# Altium schematic sheet Э3("All Documents",Physical)
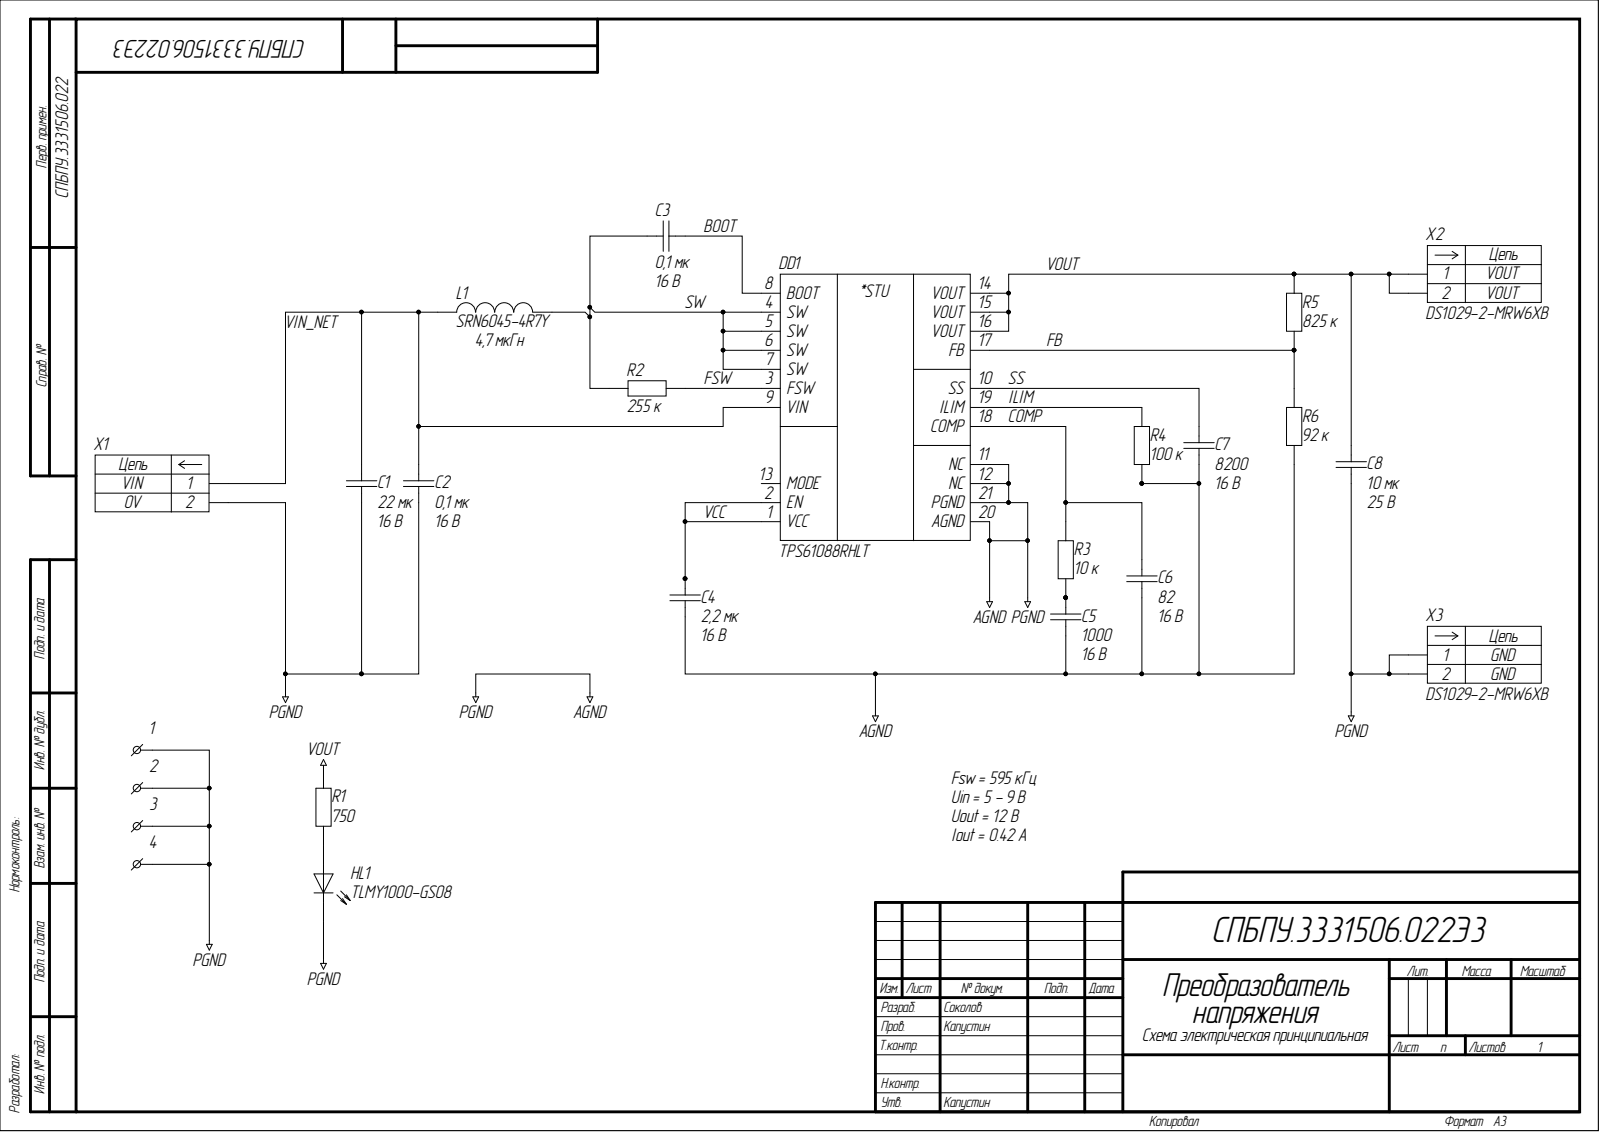
[diagram p0: Э3("All Documents",Physical)]

## Parts
1  MOUNTING_HOLE  [HOLE_1,6X3,3]  (value not drawn)
2  MOUNTING_HOLE  [HOLE_1,6X3,3]  (value not drawn)
3  MOUNTING_HOLE  [HOLE_1,6X3,3]  (value not drawn)
4  MOUNTING_HOLE  [HOLE_1,6X3,3]  (value not drawn)
C1  22 мк  [C1206]
C2  0,1 мк  Номинальное напряжение: 16 В  [C0402]
C3  0,1 мк  Номинальное напряжение: 16 В  [C0402]
C4  2,2 мк  Номинальное напряжение: 16 В  [C0603]
C5  1000  Номинальное напряжение: 16 В  [C0201]
C6  82  Номинальное напряжение: 16 В  [C0603]
C7  8200  Номинальное напряжение: 16 В  [C0402]
C8  10 мк  Номинальное напряжение: 25 В  [C0603]
DD1  TPS61088RHLT  [VQFN-20]
HL1  TLMY1000-GS08  [HL0603]
L1  SRN6045-4R7Y  Параметр номинал: 4,7 мкГн  [SRN6045_1]
R1  750  [R0603]
R2  255 к  [R0603]
R3  10 к  [R0603]
R4  100 к  [R0603]
R5  825 к  [R0603]
R6  92 к  [R0402]
X1  Contact_2: 0V  Contact_1: VIN  [DS1029-2-MRW6XB]
X2  DS1029-2-MRW6XB  Contact_2: VOUT  [DS1029-2-MRW6XB]
X3  DS1029-2-MRW6XB  Contact_2: GND  [DS1029-2-MRW6XB]

## Nets
AGND: 1.1 2.1 3.1 4.1 C1.1 C2.1 C4.1 C5.1 C6.2 C7.1 C8.1 DD1.11(NC) DD1.12(NC) DD1.20(AGND) DD1.21(PGND) HL1.1 R4.2 R6.2 X1.2 X3.1 X3.2
BOOT: C3.2 DD1.8(BOOT)
COMP: C6.1 DD1.18(COMP) R3.1
FB: DD1.17(FB) R5.2 R6.1
FSW: DD1.3(FSW) R2.2
ILIM: DD1.19(ILIM) R4.1
SS: C7.2 DD1.10(SS)
SW: C3.1 DD1.4(SW) DD1.5(SW) DD1.6(SW) DD1.7(SW) L1.2 R2.1
VCC: C4.2 DD1.1(VCC) DD1.2(EN)
VIN_NET: C1.2 C2.2 DD1.9(VIN) L1.1 X1.1
VOUT: C8.2 DD1.14(VOUT) DD1.15(VOUT) DD1.16(VOUT) R1.1 R5.1 X2.1 X2.2
NetC5_2: C5.2 R3.2
NetDD1_13: DD1.13(MODE)
NetHL1_2: HL1.2 R1.2

## Library data of the parts
1-4 = fstn0011: Параметры металлизации: 3,3 мм; Описание: Отверстие под М1,5; Наименование: Отверстие 1,6x3,3; Диаметр отверстия: 1,6 мм; Database Table Name: Монтажные элементы$; id: fstn0011; Component Kind: Standard; Library Name: kravt_lib.DbLib
C1 = c0103: =Описание; Фамилия: Паньков; Температурный коэффициент емкости: X7R; Рабочие температуры: от –55 °С до +125 °С; Производитель: YAGEO Corp.; Отклонение: ±10 %; Описание: Конденсатор чип 0805 22 мкФ 16 В ±10 %; Номинальное напряжение: 16 В; Наименование: CC1206KRX7R7BB226; Корпус: 1206; Database Table Name: Конденсаторы$; Номинал: 22 мк; Напряжение: 16 В; ID: c0103; HelpURL: https://www.yageo.com/upload/media/product/productsearch/datasheet/mlcc/UPY-GPHC_X7R_6.3V-to-50V_20.pdf; Description: Конденсатор чип 0805 22 мкФ 16 В ±10 %; Component Kind: Standard; Library Name: Database_Students.DbLib
C2 C3 = c0028: =Описание; Фамилия: Паньков; Температурный коэффициент емкости: X5R; Рабочие температуры: от –55 °С до +85 °С; Производитель: YAGEO Corp.; Отклонение: ±10 %; Описание: Конденсатор чип 0402 100 нФ 16 В ±10 %; Наименование: CC0402KRX5R7BB104; Корпус: 0402; Database Table Name: Конденсаторы$; Номинал: 0,1 мк; Напряжение: 16 В; ID: c0028; HelpURL: https://www.yageo.com/upload/media/product/productsearch/datasheet/mlcc/UPY-GPHC_X5R_4V-to-50V_26.pdf; Description: Конденсатор чип 0402 100 нФ 16 В ±10 %; Component Kind: Standard; Library Name: Database_Students.DbLib
C4 = c0069: =Описание; Фамилия: Паньков; Температурный коэффициент емкости: X5R; Рабочие температуры: от –55 °С до +85 °С; Производитель: YAGEO Corp.; Отклонение: ±10 %; Описание: Конденсатор чип 0603 2,2 мкФ 16 В ±10 %; Наименование: CC0603KRX5R7BB225; Корпус: 0603; Database Table Name: Конденсаторы$; Номинал: 2,2 мк; Напряжение: 16 В; ID: c0069; HelpURL: https://www.yageo.com/upload/media/product/productsearch/datasheet/mlcc/UPY-GPHC_X5R_4V-to-50V_26.pdf; Description: Конденсатор чип 0603 2,2 мкФ 16 В ±10 %; Component Kind: Standard; Library Name: Database_Students.DbLib
C5 = c0119: =Описание; Фамилия: Соколов; Температурный коэффициент емкости: X7R; Рабочие температуры: от –55 °С до +125 °С; Производитель: Murata Electronics; Отклонение: ±10 %; Описание: Конденсатор чип 0201 1000 пФ 16 В ±10 %; Наименование: GRM033R71C102KA01D; Корпус: 0201; Database Table Name: Конденсаторы$; Номинал: 1000; Напряжение: 16 В; ID: c0119; HelpURL: https://datasheet.octopart.com/GRM033R71C102KA01D-Murata-datasheet-14399077.pdf; Description: Конденсатор чип 0201 1000 пФ 16 В ±10 %; Component Kind: Standard; Library Name: Database_Students.DbLib
C6 = c0011: =Описание; Фамилия: Ларионов; Температурный коэффициент емкости: C0G; Рабочие температуры: от –55 °С до +125 °С; Производитель: YAGEO Corp.; Отклонение: ±5 %; Описание: Конденсатор чип 0603 82 пФ 16 В ±5 %; Наименование: CC0603JRNPO7BN820; Корпус: 0603; Database Table Name: Конденсаторы$; Номинал: 82; Напряжение: 16 В; ID: c0011; HelpURL: https://www.yageo.com/upload/media/product/productsearch/datasheet/mlcc/UPY-GP_NP0_16V-to-50V_18.pdf; Description: Конденсатор чип 0603 82 пФ 16 В ±5 %; Component Kind: Standard; Library Name: Database_Students.DbLib
C7 = c0120: =Описание; Фамилия: Соколов; Температурный коэффициент емкости: C0G; Рабочие температуры: от –55 °С до +125 °С; Производитель: Murata Electronics; Отклонение: ±5 %; Описание: Конденсатор чип 0402 8,2 нФ 50 В ±5 %; Наименование: GRM155R71C822KA01D; Корпус: 0402; Database Table Name: Конденсаторы$; Номинал: 8200; Напряжение: 16 В; ID: c0120; HelpURL: https://ru.mouser.com/datasheet/2/281/murata_03052018_GRM_Series_1-1310166.pdf; Description: Конденсатор чип 0402 8,2 нФ 50 В ±5 %; Component Kind: Standard; Library Name: Database_Students.DbLib
C8 = c0090: =Описание; Фамилия: Паньков; Температурный коэффициент емкости: X5R; Рабочие температуры: от –55 °С до +85 °С; Производитель: YAGEO Corp.; Отклонение: ±10 %; Описание: Конденсатор чип 0603 10 мкФ 25 В ±10 %; Наименование: CC0603KRX5R8BB106; Корпус: 0603; Database Table Name: Конденсаторы$; Номинал: 10 мк; Напряжение: 25 В; ID: c0090; HelpURL: https://www.yageo.com/upload/media/product/productsearch/datasheet/mlcc/UPY-GPHC_X5R_4V-to-50V_26.pdf; Description: Конденсатор чип 0603 10 мкФ 25 В ±10 %; Component Kind: Standard; Library Name: Database_Students.DbLib
DD1 = dd0009: =Описание; Частота переключения: от 200 кГц до 2,2 МГц; Фамилия: Соколов; Рабочие температуры: от -40 °С до +125 °С; Производитель: Texas Instruments; Описание: Повышающий преобразователь напряжения 12,6 и 10А; Коммутируемый ток: 10А; Выходное напряжение: от 4,5 В до 12,6 В; Входное напряжение: от 2,7 В до 12 В; Database Table Name: Преобразователи напряжения$; Наименование: TPS61088RHLT; Корпус: VQFN-20; ID: dd0009; HelpURL: https://www.ti.com/lit/ds/symlink/tps61088.pdf?ts=1606203432287&ref_url=https%253A%252F%252Fwww.google.com%252F; Description: Повышающий преобразователь напряжения 12,6 и 10А; Component Kind: Standard; Library Name: Database_Students.DbLib
HL1 = hl0003: =Описание; Цвет: Yellow; Фамилия: Ларионов; Рассеиваемая мощность: 40 мВт; Рабочие температуры: от -40 °С до +100 °С; Производитель: Vishay Intertechnology, Inc.; Описание: Светодиод чип 0603 желтый; Номинальный прямой ток: 15 мА; Номинальное падение напряжения: 1,8 В; Номинальная сила света: 7,5 мкд; Номинальная длина волны: 588 нм; Корпус: 0603; Database Table Name: Светодиоды$; Наименование: TLMY1000-GS08; ID: hl0003; HelpURL: https://www.vishay.com/leds/list/product-83172/; Description: Светодиод чип 0603 желтый; Component Kind: Standard; Library Name: Database_Students.DbLib
L1 = l0003: =Описание; Частота резонанса: 7,96 МГц; Фамилия: Соколов; Ток насыщения: 3,5 А; Рабочие температуры: от -40 °С до +125 °С; Рабочее напряжение: не указано; Производитель: Bourns, Inc.; Отклонение: ±30 %; Описание: Индуктивность 4,7 мкГн ±30 %; Номинальный ток (при нагреве на 20 °С/40 °С): 3 А; Максимальное активное сопротивление: 37.6 мОм; Корпус: 6,0 x 6,0 x 4,5; Database Table Name: Индуктивности$; Номинал: 4,7 мкГн; Наименование: SRN6045-4R7Y; ID: l0003; HelpURL: https://ru.mouser.com/datasheet/2/54/srn6045-1391386.pdf; Description: Индуктивность 4,7 мкГн ±30 %; Component Kind: Standard; Library Name: Database_Students.DbLib
R1 = r0028: =Описание; Фамилия: Паньков; Рабочие температуры: от –55 °С до +155 °С; Производитель: YAGEO Corp.; Отклонение: ±1 %; Описание: Резистор чип 0603 750 Ом ±1 % 0,1 Вт; Номинальный ток: 1 А; Номинальное напряжение: 75 В; Наименование: RC0603FR-07750RL; Корпус: 0603; Database Table Name: Резисторы$; Номинал: 750; ID: r0028; HelpURL: https://www.yageo.com/upload/media/product/productsearch/datasheet/rchip/PYu-RC_Group_51_RoHS_L_11.pdf; Description: Резистор чип 0603 750 Ом ±1 % 0,1 Вт; Component Kind: Standard; Library Name: Database_Students.DbLib
R2 = r0109: =Описание; Фамилия: Ляпцев; Рабочие температуры: от –55 °С до +155 °С; Производитель: YAGEO Corp.; Отклонение: ±1 %; Описание: Резистор чип 0603 255 кОм ±1 % 0,1 Вт; Номинальный ток: 1 А; Номинальное напряжение: 75 В; Наименование: RC0603FR-07255KL; Корпус: 0603; Database Table Name: Резисторы$; Номинал: 255 к; ID: r0109; HelpURL: https://www.yageo.com/upload/media/product/productsearch/datasheet/rchip/PYu-RC_Group_51_RoHS_L_11.pdf; Description: Резистор чип 0603 255 кОм ±1 % 0,1 Вт; Component Kind: Standard; Library Name: Database_Students.DbLib
R3 = r0017: =Описание; Фамилия: Самарин; Рабочие температуры: от -55 °С до +155 °С; Производитель: YAGEO Corp.; Отклонение: ±1 %; Описание: Резистор чип 0603 10 кОм ±1 % 0,1 Вт; Номинальный ток: 1 А; Номинальное напряжение: 75 В; Наименование: RC0603FR-0710KL; Корпус: 0603; Database Table Name: Резисторы$; Номинал: 10 к; ID: r0017; HelpURL: https://www.yageo.com/upload/media/product/productsearch/datasheet/rchip/PYu-RC_Group_51_RoHS_L_11.pdf; Description: Резистор чип 0603 10 кОм ±1 % 0,1 Вт; Component Kind: Standard; Library Name: Database_Students.DbLib
R4 = r0094: =Описание; Фамилия: Водорезов; Рабочие температуры: от –55 °С до +155 °С; Производитель: YAGEO Corp.; Отклонение: ±1 %; Описание: Резистор чип 0603 100 кОм ±1 % 0,1 Вт; Номинальный ток: 1 А; Номинальное напряжение: 75 В; Наименование: RC0603FR-07100KL; Корпус: 0603; Database Table Name: Резисторы$; Номинал: 100 к; ID: r0094; HelpURL: https://www.yageo.com/upload/media/product/productsearch/datasheet/rchip/PYu-RC_Group_51_RoHS_L_11.pdf; Description: Резистор чип 0603 100 кОм ±1 % 0,1 Вт; Component Kind: Standard; Library Name: Database_Students.DbLib
R5 = r0134: =Описание; Фамилия: Соколов; Рабочие температуры: от –55 °С до +155 °С; Производитель: YAGEO Corp.; Отклонение: ±1 %; Описание: Резистор чип 0603 825 кОм ±1 % 0,1 Вт; Номинальный ток: 1 А; Номинальное напряжение: 75 В; Наименование: RC0603FR-07825KL; Корпус: 0603; Database Table Name: Резисторы$; Номинал: 825 к; ID: r0134; HelpURL: https://www.yageo.com/upload/media/product/productsearch/datasheet/rchip/PYu-RC_Group_51_RoHS_L_11.pdf; Description: Резистор чип 0603 825 кОм ±1 % 0,1 Вт; Component Kind: Standard; Library Name: Database_Students.DbLib
R6 = r0132: =Описание; Фамилия: Соколов; Рабочие температуры: от -55 °С до +155 °С; Производитель: Yageo; Отклонение: ±1 %; Описание: Резистор чип 0402 92 кОм ±1 % 0,1 Вт; Номинальный ток: 1 А; Номинальное напряжение: 75 В; Наименование: RT0805BRD0792KL; Корпус: 0402; Database Table Name: Резисторы$; Номинал: 92 к; ID: r0132; HelpURL: https://ru.mouser.com/datasheet/2/447/PYu-RT_1-to-0.01_RoHS_L_11-1669912.pdf; Description: Резистор чип 0402 92 кОм ±1 % 0,1 Вт; Component Kind: Standard; Library Name: Database_Students.DbLib
X1-X3 = x0007: =Описание; Фамилия: Ларионов; Производитель: Connfly Electronic Co. LTD.; Описание: CWF 2 pin Type B 2,5 мм вилка угловой; Database Table Name: Разъемы$; Наименование: DS1029-2-MRW6XB; ID: x0007; HelpURL: http://www.connfly.com/productshow.aspx?id=352; Description: CWF 2 pin Type B 2,5 мм вилка угловой; Component Kind: Standard; Library Name: Database_Students.DbLib
  X2: Contact_1: VOUT
  X3: Contact_1: GND
